# Altium schematic sheet 14 MIPI DSI.SchDoc
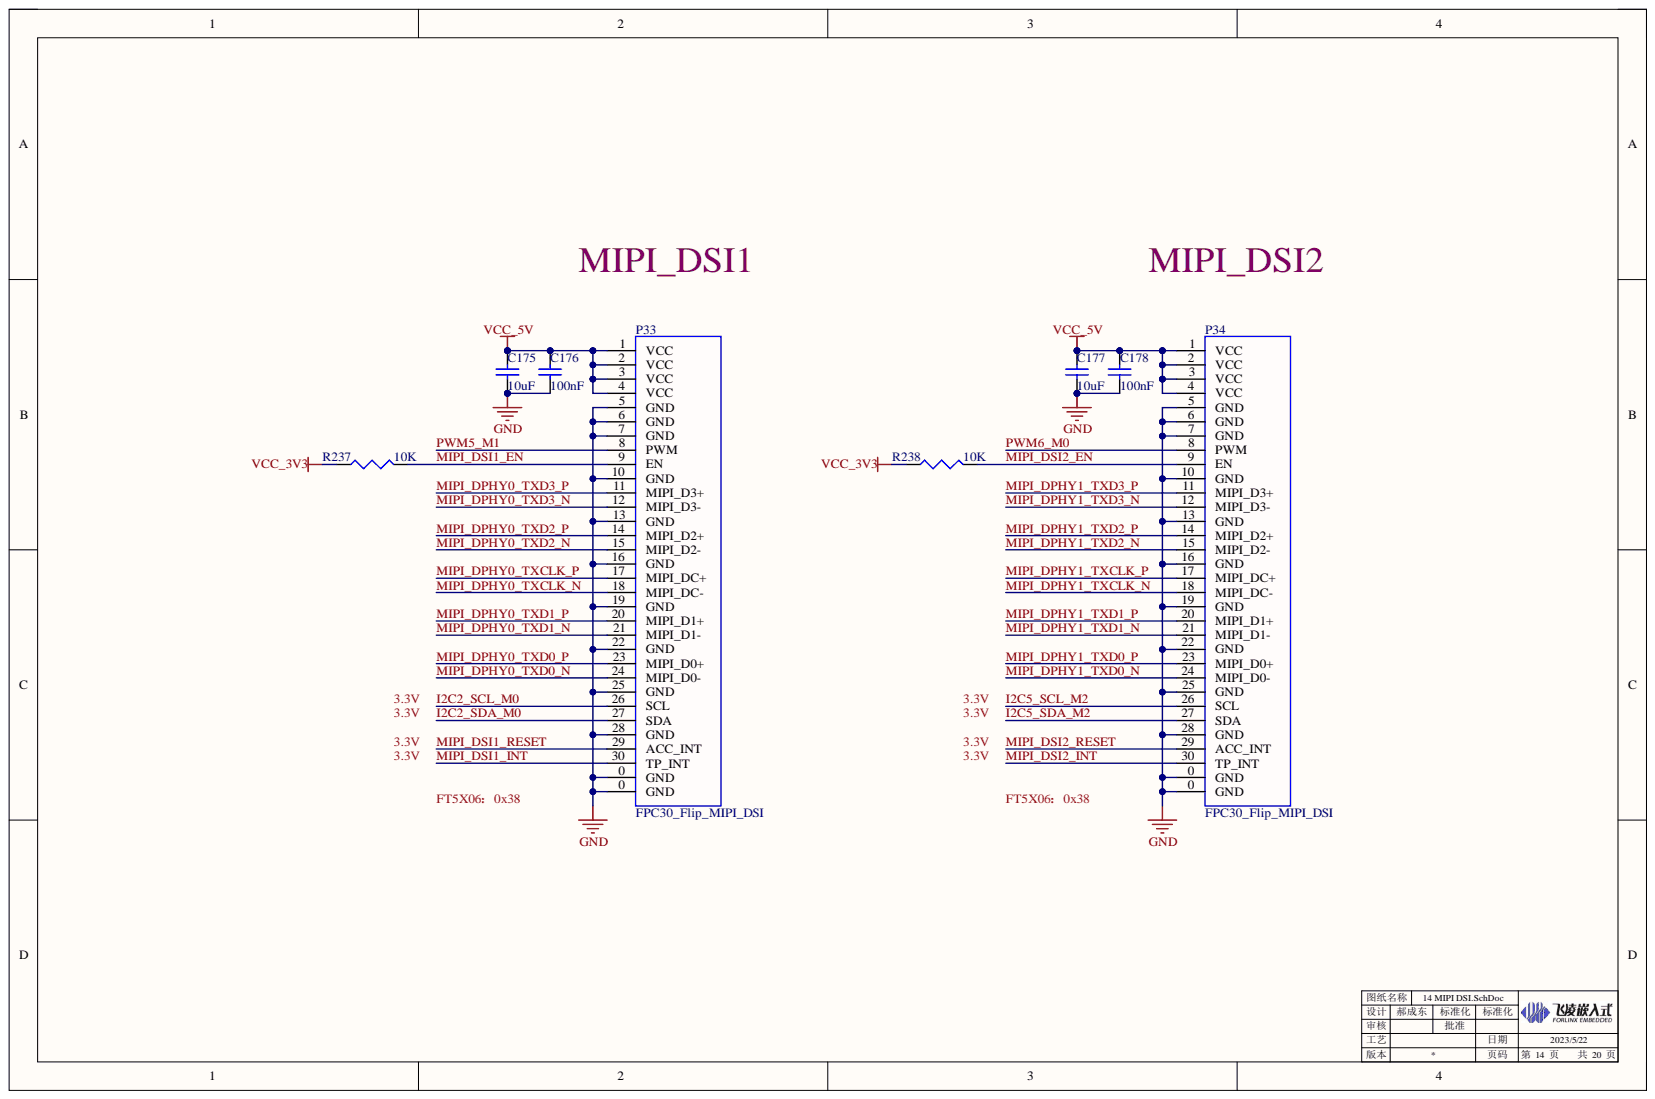
[diagram p0: 14 MIPI DSI.SchDoc]

## Parts
C175  10uF  [0603C]
C176  100nF  [0402C]
C177  10uF  [0603C]
C178  100nF  [0402C]
P33  FPC30_Flip_MIPI_DSI  [FPC30]
P34  FPC30_Flip_MIPI_DSI  [FPC30]
R237  10K  [0402R]
R238  10K  [0402R]

## Nets
GND: C175.1 C176.1 C177.1 C178.1 P33.0(GND) P33.5(GND) P33.6(GND) P33.7(GND) P33.10(GND) P33.13(GND) P33.16(GND) P33.19(GND) P33.22(GND) P33.25(GND) P33.28(GND) P34.0(GND) P34.5(GND) P34.6(GND) P34.7(GND) P34.10(GND) P34.13(GND) P34.16(GND) P34.19(GND) P34.22(GND) P34.25(GND) P34.28(GND)
VCC_3V3: R237.1 R238.1
VCC_5V: C175.2 C176.2 C177.2 C178.2 P33.1(VCC) P33.2(VCC) P33.3(VCC) P33.4(VCC) P34.1(VCC) P34.2(VCC) P34.3(VCC) P34.4(VCC)
I2C2_SCL_M0: P33.26(SCL)
I2C2_SDA_M0: P33.27(SDA)
I2C5_SCL_M2: P34.26(SCL)
I2C5_SDA_M2: P34.27(SDA)
MIPI_DPHY0_TXCLK_N: P33.18(MIPI_DC-)
MIPI_DPHY0_TXCLK_P: P33.17(MIPI_DC+)
MIPI_DPHY0_TXD0_N: P33.24(MIPI_D0-)
MIPI_DPHY0_TXD0_P: P33.23(MIPI_D0+)
MIPI_DPHY0_TXD1_N: P33.21(MIPI_D1-)
MIPI_DPHY0_TXD1_P: P33.20(MIPI_D1+)
MIPI_DPHY0_TXD2_N: P33.15(MIPI_D2-)
MIPI_DPHY0_TXD2_P: P33.14(MIPI_D2+)
MIPI_DPHY0_TXD3_N: P33.12(MIPI_D3-)
MIPI_DPHY0_TXD3_P: P33.11(MIPI_D3+)
MIPI_DPHY1_TXCLK_N: P34.18(MIPI_DC-)
MIPI_DPHY1_TXCLK_P: P34.17(MIPI_DC+)
MIPI_DPHY1_TXD0_N: P34.24(MIPI_D0-)
MIPI_DPHY1_TXD0_P: P34.23(MIPI_D0+)
MIPI_DPHY1_TXD1_N: P34.21(MIPI_D1-)
MIPI_DPHY1_TXD1_P: P34.20(MIPI_D1+)
MIPI_DPHY1_TXD2_N: P34.15(MIPI_D2-)
MIPI_DPHY1_TXD2_P: P34.14(MIPI_D2+)
MIPI_DPHY1_TXD3_N: P34.12(MIPI_D3-)
MIPI_DPHY1_TXD3_P: P34.11(MIPI_D3+)
MIPI_DSI1_EN: P33.9(EN) R237.2
MIPI_DSI1_INT: P33.30(TP_INT)
MIPI_DSI1_RESET: P33.29(ACC_INT)
MIPI_DSI2_EN: P34.9(EN) R238.2
MIPI_DSI2_INT: P34.30(TP_INT)
MIPI_DSI2_RESET: P34.29(ACC_INT)
PWM5_M1: P33.8(PWM)
PWM6_M0: P34.8(PWM)

## Library data of the parts
C175 C177 = 1110203037: Database Table Name: VALID$; 存货名称: 贴装叠层陶瓷电容; 序号: 672; 规格型号: 0603,10uF,10V,±20％,X5R; Component Kind: Standard; LatestRevisionDate: 17-Jul-2002; LatestRevisionNote: Re-released for DXP Platform.; Library Name: Database_AD Library.DbLib; PackageDescription: Radial Cap, Thru-Hole; 2 Leads; 0.3 in Pin Spacing; PackageReference: RAD-0.3; Published: 8-Jun-2000; Signal Integrity: Cap; Simulation: CAP; Value: 10uF; 存货编码: 1110203037; Publisher: Altium Limited
C176 C178 = 1110202039: Database Table Name: VALID$; 存货名称: 贴装叠层陶瓷电容; 序号: 626; 规格型号: 0402,100nF,25V,±10％,X5R; Component Kind: Standard; LatestRevisionDate: 17-Jul-2002; LatestRevisionNote: Re-released for DXP Platform.; Library Name: Database_AD Library.DbLib; PackageDescription: Radial Cap, Thru-Hole; 2 Leads; 0.3 in Pin Spacing; PackageReference: RAD-0.3; Published: 8-Jun-2000; Signal Integrity: Cap; Simulation: CAP; Value: 100nF; 存货编码: 1110202039; Publisher: Altium Limited
P33 P34 = 1120103013: 010401065; Database Table Name: VALID$; 存货名称: FPC座; 安全库存: 2271; 序号: 1185; 旧规格型号: 30P 0.5mm间距 下接翻盖; 规格型号: 30P,0.5mm,下接翻盖; Component Kind: Standard; Library Name: Database_AD Library.DbLib; Value: FPC30_Flip_MIPI_DSI; 存货编码: 1120103013; 旧编码: 010401065
R237 R238 = 1110102007: Database Table Name: VALID$; 存货名称: 贴装电阻; 序号: 17; 规格型号: 0402,10K,±5％; Component Kind: Standard; Library Name: Database_AD Library.DbLib; Signal Integrity: Res; Simulation: RESISTOR; Value: 10K; 存货编码: 1110102007
